# Altium schematic sheet Arduino-8x8 RGB Matrix.SchDoc
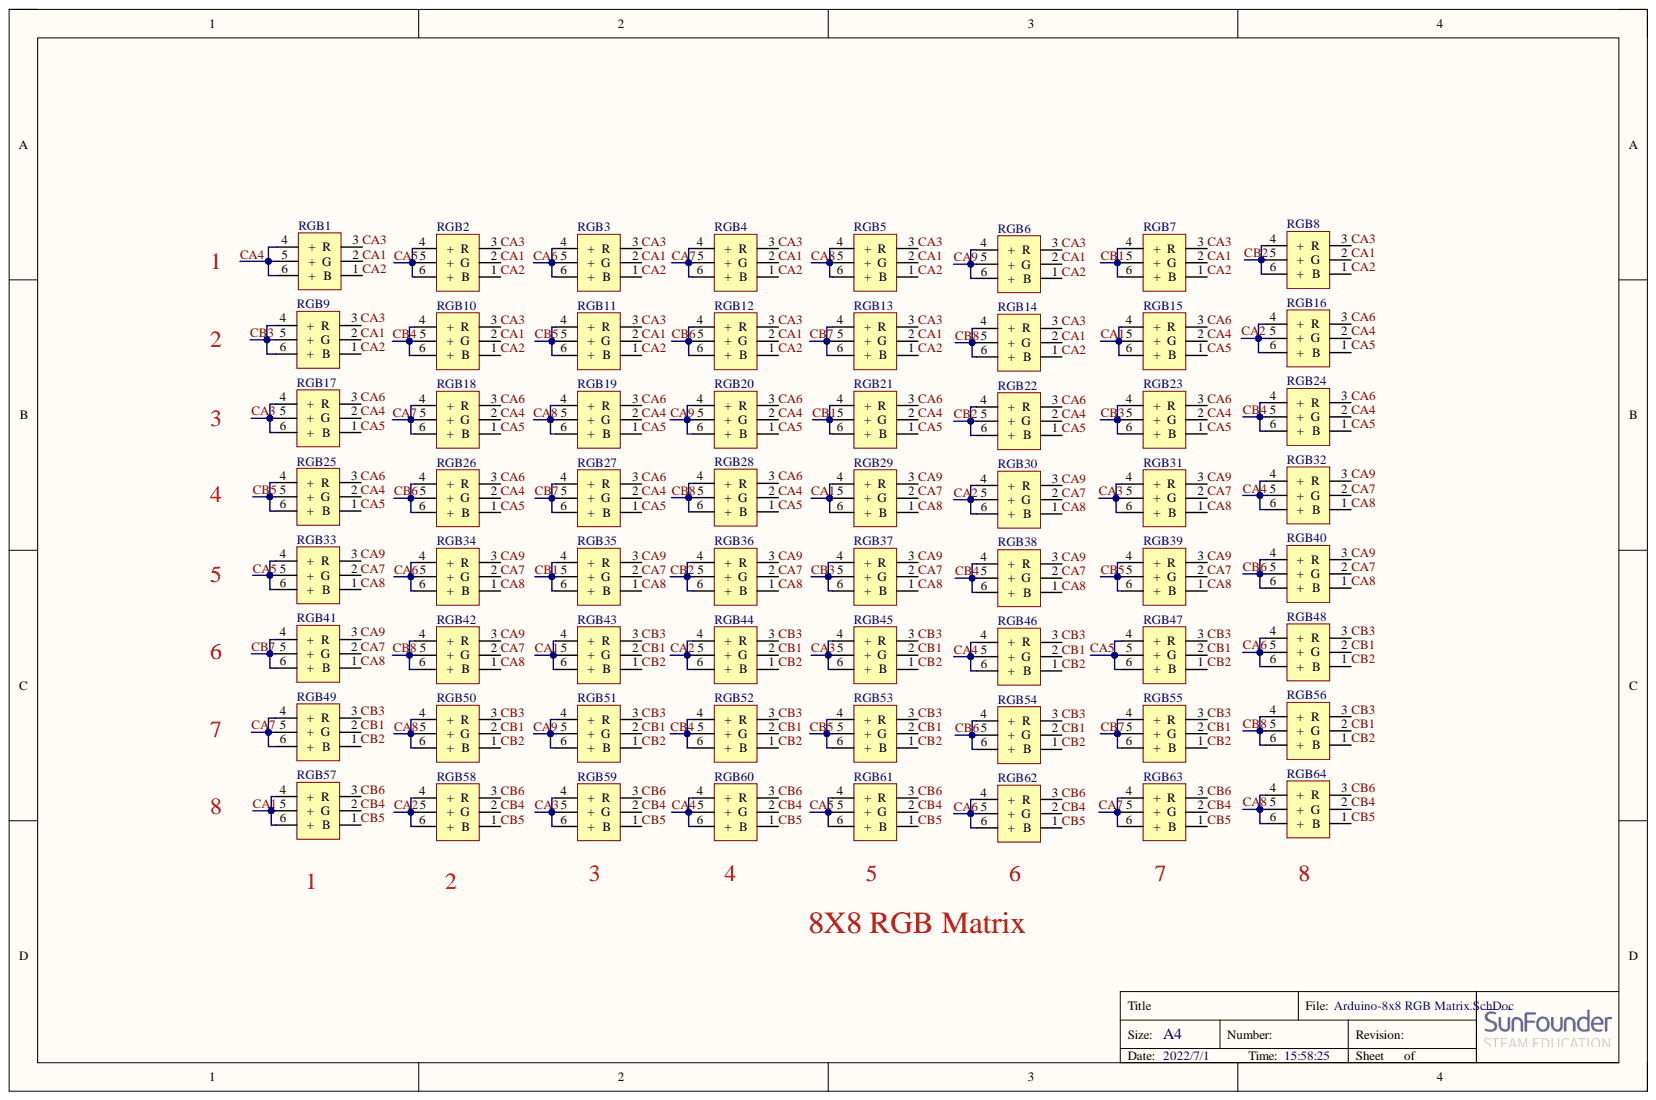
[diagram p0: Arduino-8x8 RGB Matrix.SchDoc]

## Parts
RGB1  3535RGB  [RGB 3535]  (value not drawn)
RGB2  3535RGB  [RGB 3535]  (value not drawn)
RGB3  3535RGB  [RGB 3535]  (value not drawn)
RGB4  3535RGB  [RGB 3535]  (value not drawn)
RGB5  3535RGB  [RGB 3535]  (value not drawn)
RGB6  3535RGB  [RGB 3535]  (value not drawn)
RGB7  3535RGB  [RGB 3535]  (value not drawn)
RGB8  3535RGB  [RGB 3535]  (value not drawn)
RGB9  3535RGB  [RGB 3535]  (value not drawn)
RGB10  3535RGB  [RGB 3535]  (value not drawn)
RGB11  3535RGB  [RGB 3535]  (value not drawn)
RGB12  3535RGB  [RGB 3535]  (value not drawn)
RGB13  3535RGB  [RGB 3535]  (value not drawn)
RGB14  3535RGB  [RGB 3535]  (value not drawn)
RGB15  3535RGB  [RGB 3535]  (value not drawn)
RGB16  3535RGB  [RGB 3535]  (value not drawn)
RGB17  3535RGB  [RGB 3535]  (value not drawn)
RGB18  3535RGB  [RGB 3535]  (value not drawn)
RGB19  3535RGB  [RGB 3535]  (value not drawn)
RGB20  3535RGB  [RGB 3535]  (value not drawn)
RGB21  3535RGB  [RGB 3535]  (value not drawn)
RGB22  3535RGB  [RGB 3535]  (value not drawn)
RGB23  3535RGB  [RGB 3535]  (value not drawn)
RGB24  3535RGB  [RGB 3535]  (value not drawn)
RGB25  3535RGB  [RGB 3535]  (value not drawn)
RGB26  3535RGB  [RGB 3535]  (value not drawn)
RGB27  3535RGB  [RGB 3535]  (value not drawn)
RGB28  3535RGB  [RGB 3535]  (value not drawn)
RGB29  3535RGB  [RGB 3535]  (value not drawn)
RGB30  3535RGB  [RGB 3535]  (value not drawn)
RGB31  3535RGB  [RGB 3535]  (value not drawn)
RGB32  3535RGB  [RGB 3535]  (value not drawn)
RGB33  3535RGB  [RGB 3535]  (value not drawn)
RGB34  3535RGB  [RGB 3535]  (value not drawn)
RGB35  3535RGB  [RGB 3535]  (value not drawn)
RGB36  3535RGB  [RGB 3535]  (value not drawn)
RGB37  3535RGB  [RGB 3535]  (value not drawn)
RGB38  3535RGB  [RGB 3535]  (value not drawn)
RGB39  3535RGB  [RGB 3535]  (value not drawn)
RGB40  3535RGB  [RGB 3535]  (value not drawn)
RGB41  3535RGB  [RGB 3535]  (value not drawn)
RGB42  3535RGB  [RGB 3535]  (value not drawn)
RGB43  3535RGB  [RGB 3535]  (value not drawn)
RGB44  3535RGB  [RGB 3535]  (value not drawn)
RGB45  3535RGB  [RGB 3535]  (value not drawn)
RGB46  3535RGB  [RGB 3535]  (value not drawn)
RGB47  3535RGB  [RGB 3535]  (value not drawn)
RGB48  3535RGB  [RGB 3535]  (value not drawn)
RGB49  3535RGB  [RGB 3535]  (value not drawn)
RGB50  3535RGB  [RGB 3535]  (value not drawn)
RGB51  3535RGB  [RGB 3535]  (value not drawn)
RGB52  3535RGB  [RGB 3535]  (value not drawn)
RGB53  3535RGB  [RGB 3535]  (value not drawn)
RGB54  3535RGB  [RGB 3535]  (value not drawn)
RGB55  3535RGB  [RGB 3535]  (value not drawn)
RGB56  3535RGB  [RGB 3535]  (value not drawn)
RGB57  3535RGB  [RGB 3535]  (value not drawn)
RGB58  3535RGB  [RGB 3535]  (value not drawn)
RGB59  3535RGB  [RGB 3535]  (value not drawn)
RGB60  3535RGB  [RGB 3535]  (value not drawn)
RGB61  3535RGB  [RGB 3535]  (value not drawn)
RGB62  3535RGB  [RGB 3535]  (value not drawn)
RGB63  3535RGB  [RGB 3535]  (value not drawn)
RGB64  3535RGB  [RGB 3535]  (value not drawn)

## Nets
CA1: RGB1.2(G) RGB2.2(G) RGB3.2(G) RGB4.2(G) RGB5.2(G) RGB6.2(G) RGB7.2(G) RGB8.2(G) RGB9.2(G) RGB10.2(G) RGB11.2(G) RGB12.2(G) RGB13.2(G) RGB14.2(G) RGB15.4(+) RGB15.5(+) RGB15.6(+) RGB29.4(+) RGB29.5(+) RGB29.6(+) RGB43.4(+) RGB43.5(+) RGB43.6(+) RGB57.4(+) RGB57.5(+) RGB57.6(+)
CA2: RGB1.1(B) RGB2.1(B) RGB3.1(B) RGB4.1(B) RGB5.1(B) RGB6.1(B) RGB7.1(B) RGB8.1(B) RGB9.1(B) RGB10.1(B) RGB11.1(B) RGB12.1(B) RGB13.1(B) RGB14.1(B) RGB16.4(+) RGB16.5(+) RGB16.6(+) RGB30.4(+) RGB30.5(+) RGB30.6(+) RGB44.4(+) RGB44.5(+) RGB44.6(+) RGB58.4(+) RGB58.5(+) RGB58.6(+)
CA3: RGB1.3(R) RGB2.3(R) RGB3.3(R) RGB4.3(R) RGB5.3(R) RGB6.3(R) RGB7.3(R) RGB8.3(R) RGB9.3(R) RGB10.3(R) RGB11.3(R) RGB12.3(R) RGB13.3(R) RGB14.3(R) RGB17.4(+) RGB17.5(+) RGB17.6(+) RGB31.4(+) RGB31.5(+) RGB31.6(+) RGB45.4(+) RGB45.5(+) RGB45.6(+) RGB59.4(+) RGB59.5(+) RGB59.6(+)
CA4: RGB1.4(+) RGB1.5(+) RGB1.6(+) RGB15.2(G) RGB16.2(G) RGB17.2(G) RGB18.2(G) RGB19.2(G) RGB20.2(G) RGB21.2(G) RGB22.2(G) RGB23.2(G) RGB24.2(G) RGB25.2(G) RGB26.2(G) RGB27.2(G) RGB28.2(G) RGB32.4(+) RGB32.5(+) RGB32.6(+) RGB46.4(+) RGB46.5(+) RGB46.6(+) RGB60.4(+) RGB60.5(+) RGB60.6(+)
CA5: RGB2.4(+) RGB2.5(+) RGB2.6(+) RGB15.1(B) RGB16.1(B) RGB17.1(B) RGB18.1(B) RGB19.1(B) RGB20.1(B) RGB21.1(B) RGB22.1(B) RGB23.1(B) RGB24.1(B) RGB25.1(B) RGB26.1(B) RGB27.1(B) RGB28.1(B) RGB33.4(+) RGB33.5(+) RGB33.6(+) RGB47.4(+) RGB47.5(+) RGB47.6(+) RGB61.4(+) RGB61.5(+) RGB61.6(+)
CA6: RGB3.4(+) RGB3.5(+) RGB3.6(+) RGB15.3(R) RGB16.3(R) RGB17.3(R) RGB18.3(R) RGB19.3(R) RGB20.3(R) RGB21.3(R) RGB22.3(R) RGB23.3(R) RGB24.3(R) RGB25.3(R) RGB26.3(R) RGB27.3(R) RGB28.3(R) RGB34.4(+) RGB34.5(+) RGB34.6(+) RGB48.4(+) RGB48.5(+) RGB48.6(+) RGB62.4(+) RGB62.5(+) RGB62.6(+)
CA7: RGB4.4(+) RGB4.5(+) RGB4.6(+) RGB18.4(+) RGB18.5(+) RGB18.6(+) RGB29.2(G) RGB30.2(G) RGB31.2(G) RGB32.2(G) RGB33.2(G) RGB34.2(G) RGB35.2(G) RGB36.2(G) RGB37.2(G) RGB38.2(G) RGB39.2(G) RGB40.2(G) RGB41.2(G) RGB42.2(G) RGB49.4(+) RGB49.5(+) RGB49.6(+) RGB63.4(+) RGB63.5(+) RGB63.6(+)
CA8: RGB5.4(+) RGB5.5(+) RGB5.6(+) RGB19.4(+) RGB19.5(+) RGB19.6(+) RGB29.1(B) RGB30.1(B) RGB31.1(B) RGB32.1(B) RGB33.1(B) RGB34.1(B) RGB35.1(B) RGB36.1(B) RGB37.1(B) RGB38.1(B) RGB39.1(B) RGB40.1(B) RGB41.1(B) RGB42.1(B) RGB50.4(+) RGB50.5(+) RGB50.6(+) RGB64.4(+) RGB64.5(+) RGB64.6(+)
CA9: RGB6.4(+) RGB6.5(+) RGB6.6(+) RGB20.4(+) RGB20.5(+) RGB20.6(+) RGB29.3(R) RGB30.3(R) RGB31.3(R) RGB32.3(R) RGB33.3(R) RGB34.3(R) RGB35.3(R) RGB36.3(R) RGB37.3(R) RGB38.3(R) RGB39.3(R) RGB40.3(R) RGB41.3(R) RGB42.3(R) RGB51.4(+) RGB51.5(+) RGB51.6(+)
CB1: RGB7.4(+) RGB7.5(+) RGB7.6(+) RGB21.4(+) RGB21.5(+) RGB21.6(+) RGB35.4(+) RGB35.5(+) RGB35.6(+) RGB43.2(G) RGB44.2(G) RGB45.2(G) RGB46.2(G) RGB47.2(G) RGB48.2(G) RGB49.2(G) RGB50.2(G) RGB51.2(G) RGB52.2(G) RGB53.2(G) RGB54.2(G) RGB55.2(G) RGB56.2(G)
CB2: RGB8.4(+) RGB8.5(+) RGB8.6(+) RGB22.4(+) RGB22.5(+) RGB22.6(+) RGB36.4(+) RGB36.5(+) RGB36.6(+) RGB43.1(B) RGB44.1(B) RGB45.1(B) RGB46.1(B) RGB47.1(B) RGB48.1(B) RGB49.1(B) RGB50.1(B) RGB51.1(B) RGB52.1(B) RGB53.1(B) RGB54.1(B) RGB55.1(B) RGB56.1(B)
CB3: RGB9.4(+) RGB9.5(+) RGB9.6(+) RGB23.4(+) RGB23.5(+) RGB23.6(+) RGB37.4(+) RGB37.5(+) RGB37.6(+) RGB43.3(R) RGB44.3(R) RGB45.3(R) RGB46.3(R) RGB47.3(R) RGB48.3(R) RGB49.3(R) RGB50.3(R) RGB51.3(R) RGB52.3(R) RGB53.3(R) RGB54.3(R) RGB55.3(R) RGB56.3(R)
CB4: RGB10.4(+) RGB10.5(+) RGB10.6(+) RGB24.4(+) RGB24.5(+) RGB24.6(+) RGB38.4(+) RGB38.5(+) RGB38.6(+) RGB52.4(+) RGB52.5(+) RGB52.6(+) RGB57.2(G) RGB58.2(G) RGB59.2(G) RGB60.2(G) RGB61.2(G) RGB62.2(G) RGB63.2(G) RGB64.2(G)
CB5: RGB11.4(+) RGB11.5(+) RGB11.6(+) RGB25.4(+) RGB25.5(+) RGB25.6(+) RGB39.4(+) RGB39.5(+) RGB39.6(+) RGB53.4(+) RGB53.5(+) RGB53.6(+) RGB57.1(B) RGB58.1(B) RGB59.1(B) RGB60.1(B) RGB61.1(B) RGB62.1(B) RGB63.1(B) RGB64.1(B)
CB6: RGB12.4(+) RGB12.5(+) RGB12.6(+) RGB26.4(+) RGB26.5(+) RGB26.6(+) RGB40.4(+) RGB40.5(+) RGB40.6(+) RGB54.4(+) RGB54.5(+) RGB54.6(+) RGB57.3(R) RGB58.3(R) RGB59.3(R) RGB60.3(R) RGB61.3(R) RGB62.3(R) RGB63.3(R) RGB64.3(R)
CB7: RGB13.4(+) RGB13.5(+) RGB13.6(+) RGB27.4(+) RGB27.5(+) RGB27.6(+) RGB41.4(+) RGB41.5(+) RGB41.6(+) RGB55.4(+) RGB55.5(+) RGB55.6(+)
CB8: RGB14.4(+) RGB14.5(+) RGB14.6(+) RGB28.4(+) RGB28.5(+) RGB28.6(+) RGB42.4(+) RGB42.5(+) RGB42.6(+) RGB56.4(+) RGB56.5(+) RGB56.6(+)

## Library data of the parts
RGB1-RGB64 = 3535RGB: Component Kind: Standard; Library Name: RGB dot matrix screen.SchLib
